# Altium schematic sheet MCU.SchDoc(MCU)
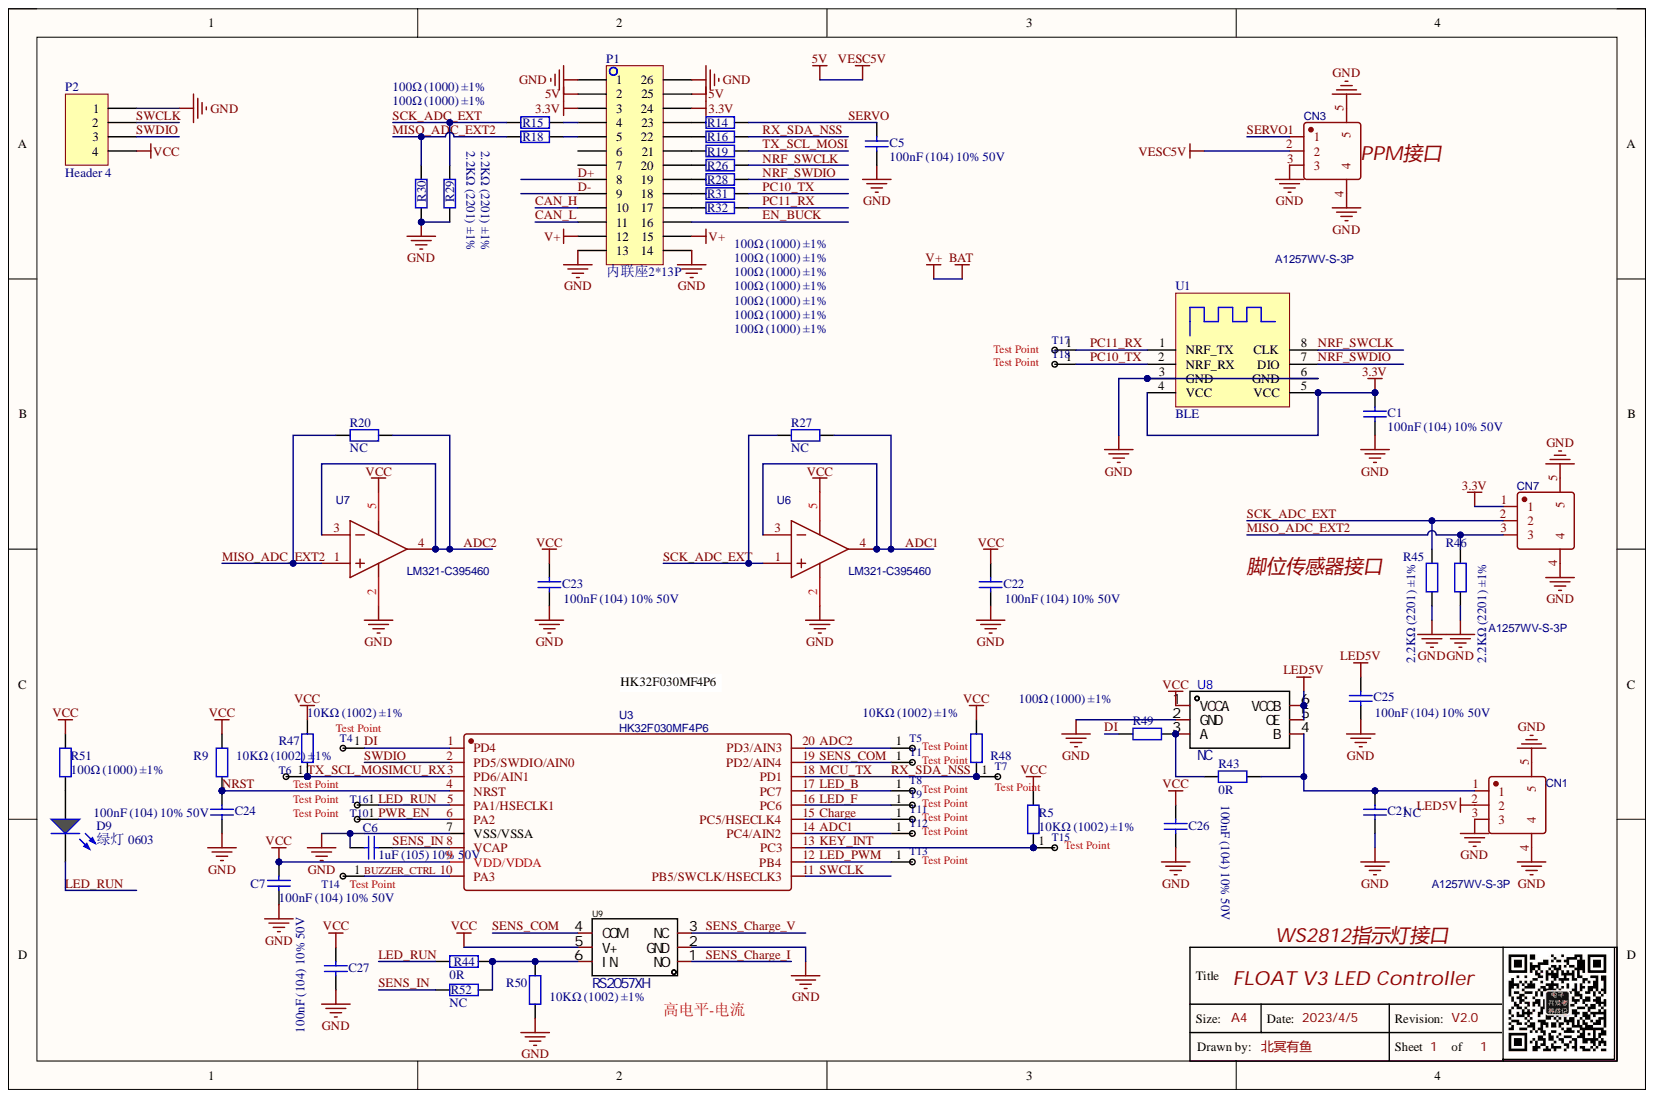
[diagram p0: MCU.SchDoc]

## Parts
C1  100nF (104) 10% 50V  [0402]
C5  100nF (104) 10% 50V  [0402]
C6  1uF (105) 10% 50V  [0603]
C7  100nF (104) 10% 50V  [0402]
C21  NC  [0402]  (value not drawn)
C22  100nF (104) 10% 50V  [0402]
C23  100nF (104) 10% 50V  [0402]
C24  100nF (104) 10% 50V  [0402]
C25  100nF (104) 10% 50V  [0402]
C26  100nF (104) 10% 50V  [0402]
C27  100nF (104) 10% 50V  [0402]
CN1  A1257WV-S-3P  [CONN-SMD_A1257WV-S-3P]
CN3  A1257WV-S-3P  [CONN-SMD_A1257WV-S-3P]
CN7  A1257WV-S-3P  [CONN-SMD_A1257WV-S-3P]
D9  绿灯 0603  [0603_LED_S1]
P1  内联座2*13P  [HEADER_0.8mm_2x3P_G]
P2  Header 4  [HDR1X4]
R5  10KΩ (1002) ±1%  [0402]
R9  10KΩ (1002) ±1%  [0402]
R14  100Ω (1000) ±1%  [0402]
R15  100Ω (1000) ±1%  [0402]
R16  100Ω (1000) ±1%  [0402]
R18  100Ω (1000) ±1%  [0402]
R19  100Ω (1000) ±1%  [0402]
R20  NC  [0402]
R26  100Ω (1000) ±1%  [0402]
R27  NC  [0402]
R28  100Ω (1000) ±1%  [0402]
R29  2.2KΩ (2201) ±1%  [0402]
R30  2.2KΩ (2201) ±1%  [0402]
R31  100Ω (1000) ±1%  [0402]
R32  100Ω (1000) ±1%  [0402]
R43  0R  [0402]
R44  0R  [0402]
R45  2.2KΩ (2201) ±1%  [0402]
R46  2.2KΩ (2201) ±1%  [0402]
R47  10KΩ (1002) ±1%  [0402]
R48  10KΩ (1002) ±1%  [0402]
R49  100Ω (1000) ±1%  [0402]
R50  10KΩ (1002) ±1%  [0402]
R51  100Ω (1000) ±1%  [0402]
R52  NC  [0402]
T1  Test Point  [TESTPOINT_1MM - small]
T4  Test Point  [TESTPOINT_1MM - PAD]
T5  Test Point  [TESTPOINT_1MM - PAD]
T6  Test Point  [TESTPOINT_1MM - PAD]
T7  Test Point  [TESTPOINT_1MM - PAD]
T8  Test Point  [TESTPOINT_1MM - PAD]
T9  Test Point  [TESTPOINT_1MM - PAD]
T10  Test Point  [TESTPOINT_1MM - PAD]
T11  Test Point  [TESTPOINT_1MM - PAD]
T12  Test Point  [TESTPOINT_1MM - PAD]
T13  Test Point  [TESTPOINT_1MM - PAD]
T14  Test Point  [TESTPOINT_1MM - PAD]
T15  Test Point  [TESTPOINT_1MM - PAD]
T16  Test Point  [TESTPOINT_1MM - PAD]
T17  Test Point  [TESTPOINT_1MM - PAD]
T18  Test Point  [TESTPOINT_1MM - PAD]
U1  BLE  [BLE]
U3  HK32F030MF4P6  [TSSOP-20_L6.5-W4.4-P0.65-LS6.4-BL]
U6  LM321-C395460  [SOT-23-5_L3.0-W1.7-P0.95-LS2.8-BL]
U7  LM321-C395460  [SOT-23-5_L3.0-W1.7-P0.95-LS2.8-BL]
U8  NC  [SOT-363-6_L2.0-W1.3-P0.65-LS2.3-BL]
U9  RS2057XH  [SOT-23-6_L2.9-W1.6-P0.95-LS2.8-BR]

## Nets
3.3V: C1.2 CN7.1 P1.3 P1.24 U1.4(VCC) U1.5(VCC)
5V: CN3.2 P1.2 P1.25
BAT: P1.12 P1.15
GND: C1.1 C5.1 C6.1 C7.1 C21.1 C22.1 C23.1 C24.1 C25.1 C26.1 C27.1 CN1.3 CN1.4 CN1.5 CN3.3 CN3.4 CN3.5 CN7.4 CN7.5 P1.1 P1.13 P1.14 P1.26 P2.1 R29.1 R30.1 R45.1 R46.1 R50.2 U1.3(GND) U1.6(GND) U3.7(VSS/VSSA) U6.2 U7.2 U8.2(GND) U9.2(GND)
LED5V: C25.2 CN1.2 U8.5(OE) U8.6(VCCB)
VCC: C7.2 C22.2 C23.2 C26.2 C27.2 P2.4 R5.1 R9.1 R47.1 R48.1 R51.2 U3.9(VDD/VDDA) U6.5 U7.5 U8.1(VCCA) U9.5(V+)
ADC1: R27.2 T12.1 U3.14(PC4/AIN2) U6.3 U6.4
ADC2: R20.2 T5.1 U3.20(PD3/AIN3) U7.3 U7.4
BUZZER_CTRL: T14.1 U3.10(PA3)
CAN_H: P1.10
CAN_L: P1.11
Charge: T11.1 U3.15(PC5/HSECLK4)
D+: P1.8
D-: P1.9
DI: R49.1 T4.1 U3.1(PD4)
EN_BUCK: P1.16
KEY_INT: R5.2 T15.1 U3.13(PC3)
LED_B: T8.1 U3.17(PC7)
LED_F: T9.1 U3.16(PC6)
LED_PWM: T13.1 U3.12(PB4)
LED_RUN: D9.K R44.1 T16.1 U3.5(PA1/HSECLK1)
MCU_RX: R19.1 R47.2 T6.1 U3.3(PD6/AIN1)
MCU_TX: R16.1 R48.2 T7.1 U3.18(PD1)
MISO_ADC_EXT2: CN7.3 R18.1 R20.1 R30.2 R46.2 U7.1
NRF_SWCLK: R26.1 U1.8(CLK)
NRF_SWDIO: R28.1 U1.7(DIO)
NRST: C24.2 R9.2 U3.4(NRST)
PC10_TX: R31.1 T18.1 U1.2(NRF_RX)
PC11_RX: R32.1 T17.1 U1.1(NRF_TX)
PWR_EN: T10.1 U3.6(PA2)
SCK_ADC_EXT: CN7.2 R15.1 R27.1 R29.2 R45.2 U6.1
SENS_Charge_I: U9.1(NO)
SENS_Charge_V: U9.3(NC)
SENS_COM: T1.1 U3.19(PD2/AIN4) U9.4(COM)
SENS_IN: C6.2 R52.1 U3.8(VCAP)
SERVO: C5.2 CN3.1 R14.1
SWCLK: P2.2 U3.11(PB5/SWCLK/HSECLK3)
SWDIO: P2.3 U3.2(PD5/SWDIO/AIN0)
NetC21_2: C21.2 CN1.1 R43.2 U8.4(B)
NetD9_A: D9.A R51.1
NetP1_4: P1.4 R15.2
NetP1_5: P1.5 R18.2
NetP1_17: P1.17 R32.2
NetP1_18: P1.18 R31.2
NetP1_19: P1.19 R28.2
NetP1_20: P1.20 R26.2
NetP1_21: P1.21 R19.2
NetP1_22: P1.22 R16.2
NetP1_23: P1.23 R14.2
NetR43_1: R43.1 R49.2 U8.3(A)
NetR44_2: R44.2 R50.1 R52.2 U9.6(IN)

Not connected: P1 6 7

## Library data of the parts
C1 C5 C7 C24 = 0603 100nF (104) 10% 50V: 贴片电容; Component Kind: Standard; ComponentLink1Description: 供应商链接; ComponentLink1URL: http://www.szlcsc.com/product/details_15331.html; Package: 0603; Supplier: LC; SuppliersPartNumber: C14663
  C1 C7 C24: Library Name: Miscellaneous Devices LC.IntLib
  C5: Library Name: Cap.SchLib
C6 = 0603 1uF (105) 10% 50V: 贴片电容; Component Kind: Standard; ComponentLink1Description: 供应商链接; ComponentLink1URL: http://www.szlcsc.com/product/details_16531.html; Library Name: Miscellaneous Devices LC.IntLib; Package: 0603; Supplier: LC; SuppliersPartNumber: C15849
C21 = 0402 220pF (221) 10% 50V: 贴片电容; Component Kind: Standard; ComponentLink1Description: 供应商链接; ComponentLink1URL: http://www.szlcsc.com/product/details_1882.html; Library Name: Cap.SchLib; Package: 0402; Supplier: LC; SuppliersPartNumber: C1530
C22 C23 C25-C27 = 0402 100nF (104) 10% 16V: 贴片电容; Component Kind: Standard; ComponentLink1Description: 供应商链接; ComponentLink1URL: http://www.szlcsc.com/product/details_1877.html; Library Name: Cap.SchLib; Package: 0402; Supplier: LC; SuppliersPartNumber: C1525
CN1 CN3 CN7 = A1257WV-S-3P: BOM_Manufacturer: Changjiang Connectors; Contributor: LCSC; BOM_JLCPCB Part Class: Extended Part; BOM_Manufacturer Part: A1257WV-S-3P; BOM_Supplier: LCSC; BOM_Supplier Part: C225119; Component Kind: Standard; Library Name: SCH.SchLib; spicePre: C; spiceSymbolName: A1257WV-S-3P
D9 = 0603 绿灯: 发光二极管; Component Kind: Standard; ComponentLink1Description: 供应商链接; ComponentLink1URL: http://www.szlcsc.com/product/details_73152.html; Library Name: LED.SchLib; Package: 0603; Supplier: LC; SuppliersPartNumber: C72043
P2 = Header 4: Header, 4-Pin; Component Kind: Standard; LatestRevisionDate: 17-Jul-2002; LatestRevisionNote: Re-released for DXP Platform.; Library Name: Miscellaneous Connectors.IntLib; Signal Integrity: Connector; Publisher: Altium Limited
R5 R9 R47 R48 R50 = 0603 10KΩ (1002) 1%: 贴片电阻; Component Kind: Standard; ComponentLink1Description: 供应商链接; ComponentLink1URL: http://www.szlcsc.com/product/details_26547.html; Library Name: Miscellaneous Devices LC.IntLib; Package: 0603; Supplier: LC; SuppliersPartNumber: C25804
R14-R16 R18 R19 R26 R28 R31 R32 R49 R51 = 0603 100Ω (1000) 1%: 贴片电阻; Component Kind: Standard; ComponentLink1Description: 供应商链接; ComponentLink1URL: http://www.szlcsc.com/product/details_23502.html; Library Name: RES.SchLib; Package: 0603; Supplier: LC; SuppliersPartNumber: C22775
R20 R27 R43 R44 R52 = 0402 0Ω (0R0) 1%: 贴片电阻; Component Kind: Standard; ComponentLink1Description: 供应商链接; ComponentLink1URL: http://www.szlcsc.com/product/details_17853.html; Library Name: RES.SchLib; Package: 0402; Supplier: LC; SuppliersPartNumber: C17168
R29 R30 R45 R46 = 0603 2.2KΩ (2201) 1%: 贴片电阻; Component Kind: Standard; ComponentLink1Description: 供应商链接; ComponentLink1URL: http://www.szlcsc.com/product/details_4597.html; Library Name: RES.SchLib; Package: 0603; Supplier: LC; SuppliersPartNumber: C4190
T1 T4-T18 = U: Component Kind: Standard
U3 = HK32F030MF4P6: BOM_Manufacturer: HK; BOM_Paste Type: expand; Contributor: LCSC; BOM_Manufacturer Part: HK32F030MF4P6; BOM_Supplier: LCSC; BOM_Supplier Part: C707444; Component Kind: Standard; spicePre: U; spiceSymbolName: HK32F030MF4P6
U6 U7 = LM321-C395460: BOM_Manufacturer: IDCHIP; BOM_Manufacturer Part: LM321; Contributor: LCSC; BOM_JLCPCB Part Class: Extended Part; BOM_Supplier: LCSC; BOM_Supplier Part: C395460; Component Kind: Standard; spicePre: U; spiceSymbolName: LM321-C395460
U8 = RS0101YC6: 原RS0101YC6; Manufacturer: RUNIC(润石); Add into BOM: yes; Component Kind: Standard; Convert to PCB: yes; Datasheet: https://atta.szlcsc.com/upload/public/pdf/source/20210326/C2762287_40A1E4BC86497B21D77F153C09AF2736.pdf; Device: RS0101YC6; JLCPCB Part Class: Extended Part; LCSC Part Name: RS0101YC6; Manufacturer Part: RS0101YC6; Name: RS0101YC6; PCB3D: SOT-363-6_L2.0-W1.3-P0.65-LS2.3-BL; Supplier: LCSC; Supplier Footprint: SOT-363; Supplier Part: C2762286; Symbol: RS0101YC6
U9 = RS2057XH: Manufacturer: RUNIC(润石); Add into BOM: yes; Component Kind: Standard; Convert to PCB: yes; Datasheet: https://atta.szlcsc.com/upload/public/pdf/source/20180801/C255474_BEC2B8DC86FF83F7025C2A877C3ED78A.pdf; Device: RS2057XH; JLCPCB Part Class: Extended Part; LCSC Part Name: 模拟开关RS2057XH; Manufacturer Part: RS2057XH; Name: RS2057XH; PCB3D: SOT-23-6_L2.9-W1.6-H1.5-LS2.8-P0.95; Supplier: LCSC; Supplier Footprint: SOT-23-6; Supplier Part: C255474; Switch Circuit: -; Symbol: RS2057XH
P1 = 内联座2*13P: Component Kind: Standard; Description: 内联座2*13P; LatestRevisionDate: 17-Jul-2002; LatestRevisionNote: Re-released for DXP Platform.; Library Name: SCH.SchLib; Signal Integrity: Connector; Publisher: Altium Limited
U1 = BLE: Component Kind: Standard; Description: BLE; Library Name: LCM.SchLib
